# Altium schematic sheet Trottinette_Telemetrie_Circuit.SchDoc(Trottinette_Telemetrie_Circuit)
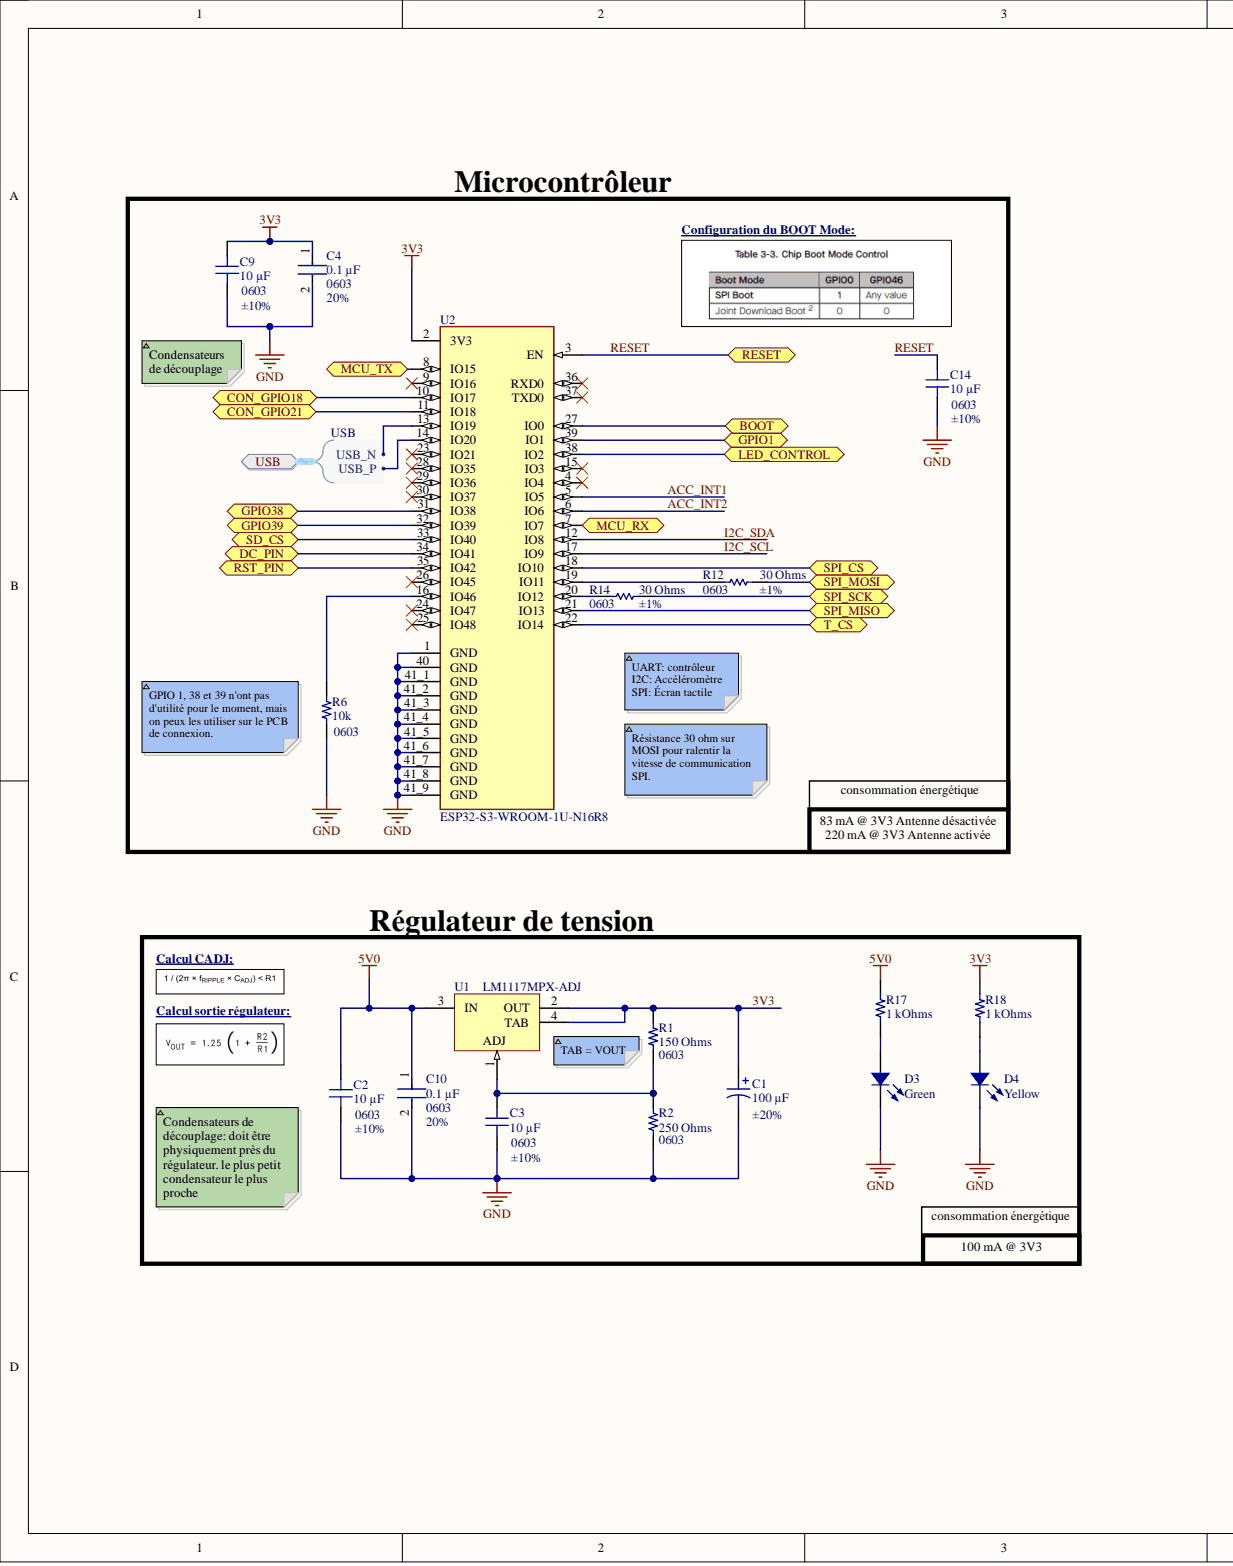
[diagram p0: Trottinette_Telemetrie_Circuit.SchDoc, left]
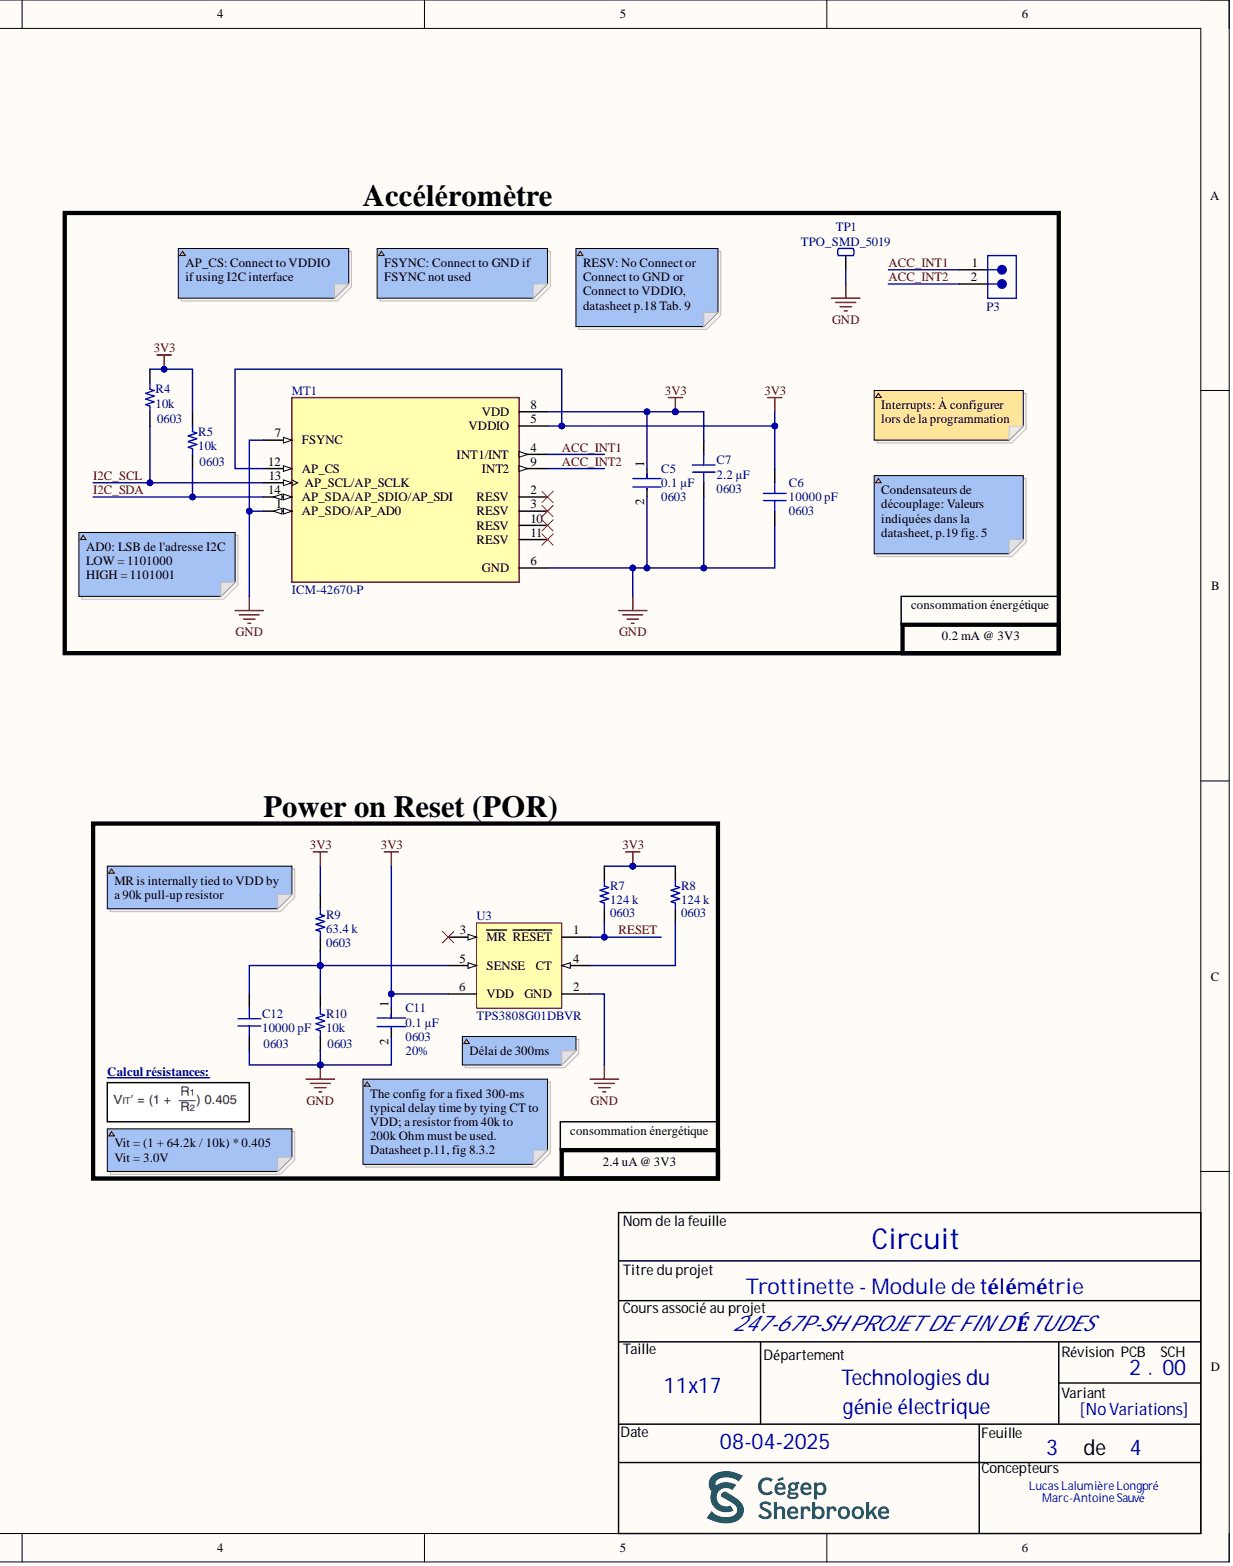
[diagram p1: Trottinette_Telemetrie_Circuit.SchDoc, right]

## Parts
C1  100 µF  Tolerance: ±20%  [CAP ALSMD 6.6MM H6.1MM]
C2  10 µF  Device Package: 0603  Tolerance: ±10%  [CAP 0603_1608]
C3  10 µF  Device Package: 0603  Tolerance: ±10%  [CAP 0603_1608]
C4  Capacitance: 0.1 µF  Case Code (Imperial): 0603  Tolerance: 20%  [FP-0603-L_1_6_0_15-W_0_81-IPC_B]  (hidden comment: 0603ZC104MAT2A)
C5  Case Code (Imperial): 0603  [FP-0603-L_1_6_0_15-W_0_81-IPC_B]  (hidden comment: 0603ZC104MAT2A)
C6  10000 pF  Package / Case: 0603  [CAP 0603_1608]
C7  2.2 µF  Package / Case: 0603  [CAP 0603_1608]
C9  10 µF  Device Package: 0603  Tolerance: ±10%  [CAP 0603_1608]
C10  Capacitance: 0.1 µF  Case Code (Imperial): 0603  Tolerance: 20%  [FP-0603-L_1_6_0_15-W_0_81-IPC_B]  (hidden comment: 0603ZC104MAT2A)
C11  Capacitance: 0.1 µF  Case Code (Imperial): 0603  Tolerance: 20%  [FP-0603-L_1_6_0_15-W_0_81-IPC_B]  (hidden comment: 0603ZC104MAT2A)
C12  10000 pF  Device Package: 0603  [CAP 0603_1608]
C14  10 µF  Device Package: 0603  Tolerance: ±10%  [CAP 0603_1608]
D3  Green  [LED 0603_1608 GREEN]
D4  Yellow  [LED 0603_1608 YELLOW]
MT1  ICM-42670-P  [XDCR_ICM-42670-P]
P3  61300211121  [61300211121]  (value not drawn)
R1  150 Ohms  Supplier Device Package: 0603  [RES 0603_1608]
R2  250 Ohms  Supplier Device Package: 0603  [RES 0603_1608]
R4  10k  Supplier Device Package: 0603  [RES 0603_1608]
R5  10k  Supplier Device Package: 0603  [RES 0603_1608]
R6  10k  Supplier Device Package: 0603  [RES 0603_1608]
R7  124 k  Supplier Device Package: 0603  [RES 0603_1608]
R8  124 k  Supplier Device Package: 0603  [RES 0603_1608]
R9  63.4 k  Supplier Device Package: 0603  [RES 0603_1608]
R10  10k  Supplier Device Package: 0603  [RES 0603_1608]
R12  ERJ3EKF30R0V  [RESC1608X55X30LL15T15]  (value not drawn)
R14  ERJ3EKF30R0V  [RESC1608X55X30LL15T15]  (value not drawn)
R17  1 kOhms  [RES 0603_1608]
R18  1 kOhms  [RES 0603_1608]
TP1  TPO_SMD_5019  [TPO_SMD_5019]
U1  LM1117MPX-ADJ  [MP04A_N]
U2  ESP32-S3-WROOM-1U-N16R8  [XCVR_ESP32-S3-WROOM-1U-N16R8]
U3  TPS3808G01DBVR  [DBV0006A_L]

## Ports
BOOT, CON_GPIO18, CON_GPIO21, DC_PIN, GPIO1, GPIO38, GPIO39, LED_CONTROL, MCU_RX, MCU_TX, RESET, RST_PIN, SD_CS, SPI_CS, SPI_MISO, SPI_MOSI, SPI_SCK, T_CS, USB, USB.USB_N, USB.USB_P

## Nets
5V0: C2.2 C10.1 R17.1 U1.3(IN)
GND: C1.2 C2.1 C3.1 C4.2 C5.2 C6.1 C7.1 C9.1 C10.2 C11.2 C12.1 C14.1 D3.2 D4.2 MT1.1(AP_SDO/AP_AD0) MT1.6(GND) MT1.7(FSYNC) R2.1 R6.1 R10.1 TP1.1 U2.1(GND) U2.40(GND) U2.41_1(GND) U2.41_2(GND) U2.41_3(GND) U2.41_4(GND) U2.41_5(GND) U2.41_6(GND) U2.41_7(GND) U2.41_8(GND) U2.41_9(GND) U3.2(GND)
3V3: C1.1 C4.1 C5.1 C6.2 C7.2 C9.2 C11.1 MT1.5(VDDIO) MT1.8(VDD) MT1.12(AP_CS) R1.2 R4.2 R5.2 R7.2 R8.2 R9.2 R18.1 U1.2(OUT) U1.4(TAB) U2.2(3V3) U3.6(VDD)
~{RESET}: C14.2 R7.1 U2.3(EN) U3.1(RESET) ; port RESET
ACC_INT1: MT1.4(INT1/INT) P3.1 U2.5(IO5)
ACC_INT2: MT1.9(INT2) P3.2 U2.6(IO6)
BOOT: U2.27(IO0) ; port BOOT
CON_GPIO18: U2.10(IO17) ; port CON_GPIO18
CON_GPIO21: U2.11(IO18) ; port CON_GPIO21
DC_PIN: U2.34(IO41) ; port DC_PIN
GPIO1: U2.39(IO1) ; port GPIO1
GPIO38: U2.31(IO38) ; port GPIO38
GPIO39: U2.32(IO39) ; port GPIO39
I2C_SCL: MT1.13(AP_SCL/AP_SCLK) R4.1 U2.17(IO9)
I2C_SDA: MT1.14(AP_SDA/AP_SDIO/AP_SDI) R5.1 U2.12(IO8)
LED_CONTROL: U2.38(IO2) ; port LED_CONTROL
MCU_RX: U2.7(IO7) ; port MCU_RX
MCU_TX: U2.8(IO15) ; port MCU_TX
RST_PIN: U2.35(IO42) ; port RST_PIN
SD_CS: U2.33(IO40) ; port SD_CS
SPI_CS: U2.18(IO10) ; port SPI_CS
SPI_MISO: U2.21(IO13) ; port SPI_MISO
SPI_MOSI: R12.2 ; port SPI_MOSI
SPI_SCK: R14.2 ; port SPI_SCK
T_CS: U2.22(IO14) ; port T_CS
USB.USB_N: U2.13(IO19) ; port USB
USB.USB_P: U2.14(IO20) ; port USB
NetC3_2: C3.2 R1.1 R2.2 U1.1
NetC12_2: C12.2 R9.1 R10.2 U3.5(SENSE)
NetD3_1: D3.1 R17.2
NetD4_1: D4.1 R18.2
NetR6_2: R6.2 U2.16(IO46)
NetR8_1: R8.1 U3.4(CT)
NetR12_1: R12.1 U2.19(IO11)
NetR14_1: R14.1 U2.20(IO12)

Not connected: MT1 2(RESV) 3(RESV) 10(RESV) 11(RESV); U2 4(IO4) 9(IO16) 15(IO3) 23(IO21) 24(IO47) 25(IO48) 26(IO45) 28(IO35) 29(IO36) 30(IO37) 36(RXD0) 37(TXD0); U3 3(MR)

## Library data of the parts
C1 = 10SGV100M6.3X6.1: CAP ALUM 100UF 20% 10V SMD; manufacturer: Rubycon; Database Table Name: Capacitors - Aluminum - Electrolytic SMT; Lifetime @ Temp.: 2000 Hrs @ 105°C; Polarization: Polar; Ripple Current @ High Frequency: 85.2 mA @ 10 kHz; Ripple Current @ Low Frequency: 71 mA @ 120 Hz; Surface Mount Land Size: 0.260" L x 0.260" W (6.60mm x 6.60mm); Applications: Automotive; Capacitance: 100 µF; Component Kind: Standard; componentlink1description: Datasheet; componentlink1url: http://www.rubycon.co.jp/en/catalog/e_pdfs/aluminum/e_sgv.pdf; componentlink2description: DigiKey Link; componentlink2url: https://www.digikey.com/en/products/detail/rubycon/10SGV100M6-3X6-1/3568604; Device Package: Radial, Can - SMD; Height - Seated (Max): 0.240" (6.10mm); lastupdated: 6/3/2024 8:16:10 PM; Library Name: Celestial Altium Library - altium_library.DbLib; Lifecycle Status: Active; Minimum Order: 1; Mounting Type: Surface Mount; Operating Temperature: -55°C ~ 105°C; Package / Case: Radial, Can - SMD; packaging: TapeAndReel; Part Number: 10SGV100M6.3X6.1; Part Status: Active; partid: 8082; price: 0.08; Ratings: AEC-Q200; series: SGV; Size / Dimension: 0.248" Dia (6.30mm); Supplier 1: DigiKey; Supplier Part Number 1: 1189-2392-1-ND; value: 100 µF; Voltage - Rated: 10 V
C2 C3 C9 C14 = GRT188R61A106KE13D: CAP CER 10UF 10V X5R 0603; manufacturer: Murata Electronics; Database Table Name: Capacitors - Ceramic - 0603; Applications: Automotive; Capacitance: 10 µF; Component Kind: Standard; componentlink1description: Datasheet; componentlink1url: https://search.murata.co.jp/Ceramy/image/img/A01X/G101/ENG/GRT188R61A106KE13-01.pdf; componentlink2description: DigiKey Link; componentlink2url: https://www.digikey.com/en/products/detail/murata-electronics/GRT188R61A106KE13D/5416733; Features: Derating Recommended; lastupdated: 2/9/2024 8:10:30 PM; Library Name: Celestial Altium Library - altium_library.DbLib; Lifecycle Status: Active; Minimum Order: 1; Mounting Type: Surface Mount, MLCC; Operating Temperature: -55°C ~ 85°C; Package / Case: 0603 (1608 Metric); packaging: TapeAndReel; Part Number: GRT188R61A106KE13D; Part Status: Active; partid: 2522; price: 0.06; Ratings: AEC-Q200; series: GRT; Size / Dimension: 0.063" L x 0.031" W (1.60mm x 0.80mm); Supplier 1: DigiKey; Supplier Part Number 1: 490-12311-1-ND; Temperature Coefficient: X5R; Thickness (Max): 0.037" (0.95mm); Value: 10 µF; Voltage - Rated: 10V
C4 C10 C11 = CMP-2006-04550-2: General Purpose Ceramic Capacitor, 0603, 100nF, 20%, X7R, 15%, 10V; Case Code (Metric): 1608; Case/Package: 0603; Component Kind: Standard; Construction: Flat; Depth: 0.81mm; Dielectric: X7R; Lead Free: Lead Free; Lead Pitch: 0.014inch; Length: 1.6mm; Library Name: Altium Content Vault; Max Operating Temperature: 125°C; Min Operating Temperature: -55°C; Mount: Surface Mount; Number of Pins: 2; Package Quantity: 4000; Packaging: Tape and Reel; Radiation Hardening: No; RoHS Compliant: Yes; Series: -; Termination: SMD/SMT; Thickness: 0.035inch; Voltage Rating: 10V; Voltage Rating (DC): 10V; Width: 0.032inch
C5 = CMP-2006-04550-2: General Purpose Ceramic Capacitor, 0603, 100nF, 20%, X7R, 15%, 10V; Capacitance: 0.1 µF; Case Code (Metric): 1608; Case/Package: 0603; Component Kind: Standard; Construction: Flat; Depth: 0.81mm; Dielectric: X7R; Lead Free: Lead Free; Lead Pitch: 0.014inch; Length: 1.6mm; Library Name: Altium Content Vault; Max Operating Temperature: 125°C; Min Operating Temperature: -55°C; Mount: Surface Mount; Number of Pins: 2; Package Quantity: 4000; Packaging: Tape and Reel; Radiation Hardening: No; RoHS Compliant: Yes; Series: -; Termination: SMD/SMT; Thickness: 0.035inch; Tolerance: 20%; Voltage Rating: 10V; Voltage Rating (DC): 10V; Width: 0.032inch
C6 = C0603C103K4RECAUTO: CAP CER 0603 10NF 16V X7R 10%; manufacturer: KEMET; Database Table Name: Capacitors - Ceramic - 0603; Applications: Automotive, ESD Protection; Capacitance: 10000 pF; Component Kind: Standard; componentlink1description: Datasheet; componentlink1url: https://api.kemet.com/component-edge/download/datasheet/C0603C103K4RECAUTO.pdf; componentlink2description: DigiKey Link; componentlink2url: https://www.digikey.com/en/products/detail/kemet/C0603C103K4RECAUTO/8640474; Device Package: 0603 (1608 Metric); lastupdated: 2/9/2024 8:10:22 PM; Library Name: Celestial Altium Library - altium_library.DbLib; Lifecycle Status: Active; Minimum Order: 1; Mounting Type: Surface Mount, MLCC; Operating Temperature: -55°C ~ 125°C; packaging: TapeAndReel; Part Number: C0603C103K4RECAUTO; Part Status: Active; partid: 8251; price: 0.01; Ratings: AEC-Q200; series: ESD SMD Auto X7R; Size / Dimension: 0.063" L x 0.031" W (1.60mm x 0.80mm); Supplier 1: DigiKey; Supplier Part Number 1: 399-17880-1-ND; Temperature Coefficient: X7R; Thickness (Max): 0.034" (0.87mm); Tolerance: ±10%; Value: 10000 pF; Voltage - Rated: 16V
C7 = CC0603ZRY5V7BB225: CAP CER 2.2UF 16V Y5V 0603; manufacturer: Yageo; Database Table Name: Capacitors - Ceramic - 0603; Temperature Coefficient: Y5V (F); Tolerance: -20%, +80%; Applications: General Purpose; Capacitance: 2.2 µF; Component Kind: Standard; componentlink1description: Datasheet; componentlink1url: http://www.yageo.com/documents/recent/UPY-GPHC_Y5V_6.3V-to-50V_8.pdf; componentlink2description: DigiKey Link; componentlink2url: https://www.digikey.com/en/products/detail/yageo/CC0603ZRY5V7BB225/5883851; Device Package: 0603 (1608 Metric); lastupdated: 2/9/2024 8:10:30 PM; Library Name: Celestial Altium Library - altium_library.DbLib; Lifecycle Status: Active; Minimum Order: 1; Mounting Type: Surface Mount, MLCC; Operating Temperature: -30°C ~ 85°C; packaging: TapeAndReel; Part Number: CC0603ZRY5V7BB225; Part Status: Active; partid: 2203; price: 0.01; series: CC; Size / Dimension: 0.063" L x 0.031" W (1.60mm x 0.80mm); Supplier 1: DigiKey; Supplier Part Number 1: 311-3416-1-ND; Thickness (Max): 0.035" (0.90mm); Value: 2.2 µF; Voltage - Rated: 16V
C12 = AC0603KRX7R0BB103: CAP CER 10000PF 100V X7R 0603; manufacturer: Yageo; Database Table Name: Capacitors - Ceramic - 0603; Tolerance: ±10%; Applications: Automotive; Capacitance: 10000 pF; Component Kind: Standard; componentlink1description: Datasheet; componentlink1url: http://www.yageo.com/NewPortal/_en/search/productDocs.jsp?YageoPartNumber=AC0603KRX7R0BB103; componentlink2description: DigiKey Link; componentlink2url: https://www.digikey.com/en/products/detail/yageo/AC0603KRX7R0BB103/6643086; lastupdated: 2024-02-09 20:10:30; Library Name: Celestial Altium Library - altium_library.DbLib; Lifecycle Status: Active; Minimum Order: 1; Mounting Type: Surface Mount, MLCC; Operating Temperature: -55°C ~ 125°C; Package / Case: 0603 (1608 Metric); packaging: TapeAndReel; Part Number: AC0603KRX7R0BB103; Part Status: Active; partid: 2218; price: 0.01; Ratings: AEC-Q200; series: AC; Size / Dimension: 0.063" L x 0.031" W (1.60mm x 0.80mm); Supplier 1: DigiKey; Supplier Part Number 1: 311-3100-1-ND; Temperature Coefficient: X7R; Thickness (Max): 0.035" (0.90mm); Value: 10000 pF; Voltage - Rated: 100V
D3 = 5973316507F: LED GREEN 0603 SMD; Manufacturer: Dialight; Configuration: Standard; Current - Test: 20mA; Database Table Name: LED - Indication; Lens Color: 1.24mm; Lens Style: Round with Domed Top; Millicandela Rating: 34mcd; Voltage - Forward (Vf) (Typ): 2V; Wavelength - Dominant: 560nm; Color: Green; Component Kind: Standard; ComponentLink1Description: Datasheet; ComponentLink1URL: http://www.dialightsignalsandcomponents.com/Assets/Drawings/2D_Drawings_DrawingDetailedSpec/NewDrawings/C18371C.pdf; ComponentLink2Description: DigiKey Link; ComponentLink2URL: https://www.digikey.com/en/products/detail/dialight/5973316507F/9385428; Device Package: 0603 (1608 Metric); Height (Max): 1.24mm; LastUpdated: 6/3/2024 7:58:53 PM; Library Name: Celestial Altium Library - altium_library.DbLib; Lifecycle Status: Active; Minimum Order: 1; Mounting Type: Surface Mount; Package / Case: 0603 (1608 Metric); Packaging: TapeAndReel; Part Number: 5973316507F; Part Status: Active; PartId: 587; Price: 0.13; Series: 597; Size / Dimension: 1.60mm L x 0.80mm W; Supplier 1: DigiKey; Supplier Device Package: 0603; Supplier Part Number 1: 350-4373-1-ND; Value: Green
D4 = 5990040007F: LED YELLOW 0603 SMD; Manufacturer: Dialight; Configuration: Standard; Current - Test: 20mA; Database Table Name: LED - Indication; Lens Color: 0.80mm; Lens Size: 1.10mm x 0.80mm; Lens Style: Rectangle with Flat Top; Millicandela Rating: 105mcd; Viewing Angle: 140°; Voltage - Forward (Vf) (Typ): 2.1V; Wavelength - Dominant: 590nm; Color: Yellow; Component Kind: Standard; ComponentLink1Description: Datasheet; ComponentLink1URL: http://www.dialightsignalsandcomponents.com/Assets/Brochures_And_Catalogs/Indication/CBI_SMD_new/Dialight_CBI_data_599-0603_Apr2018.pdf; ComponentLink2Description: DigiKey Link; ComponentLink2URL: https://www.digikey.com/en/products/detail/dialight/5990040007F/9385404; Device Package: 0603 (1608 Metric); Height (Max): 0.80mm; LastUpdated: 6/3/2024 8:01:10 PM; Library Name: Celestial Altium Library - altium_library.DbLib; Lifecycle Status: Active; Minimum Order: 1; Mounting Type: Surface Mount; Package / Case: 0603 (1608 Metric); Packaging: TapeAndReel; Part Number: 5990040007F; Part Status: Active; PartId: 83; Price: 0.04; Series: 599; Size / Dimension: 1.60mm L x 0.80mm W; Supplier 1: DigiKey; Supplier Device Package: 0603; Supplier Part Number 1: 350-4349-1-ND; Value: Yellow
P3 = 61300211121: WR-PHD Pin Header, THT, Vertical, pitch 2.54mm, 1 Row, 2P; Manufacturer: Wurth Elektronik; Contact Resistance: 20mOhm; Rated Current: 3A; Withstanding Voltage: 500V; Working Voltage: 250V; Category: Board-to-Board Connectors; Component Kind: Standard; ComponentLink1Description: Website Link; ComponentLink1URL: https://www.we-online.com/redexpert/article/61300211121?ad; ComponentLink2Description: Datasheet Link; ComponentLink2URL: https://www.we-online.com/redexpert/spec/61300211121?ad; Gender: Pin Header; Library Name: Altium_WR-PHD_rev24a.IntLib; Manufacturer Part Number: 61300211121; Match Code: WR-PHD; Mount: Through Hole; Number of Pins: 2; Number of Rows: 1; Operating Temperature Max: 105°C; Operating Temperature Min: -40°C; Orientation: Vertical; Packaging: Bag; Pitch: 2.54mm
R1 = RMCF0603FT150R: RES 150 OHM 1% 1/10W 0603; Manufacturer: Stackpole Electronics Inc; Database Table Name: Resistors - Surface Mount - 0603; Power (Watts): 0.1W, 1/10W; Temperature Coefficient: ±100ppm/°C; Component Kind: Standard; ComponentLink1Description: Datasheet; ComponentLink1URL: https://www.seielect.com/catalog/sei-rmcf_rmcp.pdf; ComponentLink2Description: DigiKey Link; ComponentLink2URL: https://www.digikey.com/en/products/detail/stackpole-electronics-inc/RMCF0603FT150R/1761314; Composition: Thick Film; Device Package: 0603 (1608 Metric); Features: Automotive AEC-Q200; Height - Seated (Max): 0.022" (0.55mm); LastUpdated: 2/9/2024 8:43:14 PM; Library Name: Celestial Altium Library - altium_library.DbLib; Lifecycle Status: Active; Minimum Order: 1; Number of Terminations: 2; Operating Temperature: -55°C ~ 155°C; Package / Case: 0603 (1608 Metric); Packaging: CutTape; Part Number: RMCF0603FT150R; Part Status: Active; PartId: 10675; Price: 0.01; Ratings: AEC-Q200; Resistance: 150 Ohms; Series: RMCF; Size / Dimension: 0.061" L x 0.031" W (1.55mm x 0.80mm); Supplier 1: DigiKey; Supplier Part Number 1: RMCF0603FT150RCT-ND; Tolerance: ±1%; Value: 150 Ohms
R2 = CH0603-250RJNTA: RES 250 OHM 5% 1/8W 0603; Manufacturer: Vishay Sfernice; Database Table Name: Resistors - Surface Mount - 0603; Power (Watts): 0.125W, 1/8W; Temperature Coefficient: ±100ppm/°C; Component Kind: Standard; ComponentLink1Description: Datasheet; ComponentLink1URL: http://www.vishay.com/docs/53014/ch.pdf; ComponentLink2Description: DigiKey Link; ComponentLink2URL: https://www.digikey.com/en/products/detail/vishay-sfernice/CH0603-250RJNTA/9405751; Composition: Thin Film; Device Package: 0603 (1608 Metric); Features: RF, High Frequency; Height - Seated (Max): 0.025" (0.63mm); LastUpdated: 2/9/2024 8:43:16 PM; Library Name: Celestial Altium Library - altium_library.DbLib; Lifecycle Status: Active; Minimum Order: 1; Number of Terminations: 2; Operating Temperature: -55°C ~ 155°C; Package / Case: 0603 (1608 Metric); Packaging: TapeAndReel; Part Number: CH0603-250RJNTA; Part Status: Active; PartId: 10119; Price: 2.39; Resistance: 250 Ohms; Series: CH; Size / Dimension: 0.060" L x 0.030" W (1.52mm x 0.76mm); Supplier 1: DigiKey; Supplier Part Number 1: 716-1168-1-ND; Tolerance: ±5%; Value: 250 Ohms
R4-R6 R10 = RNCS0603BKE10K0: RES 10K OHM 0.1% 1/16W 0603; Manufacturer: Stackpole Electronics Inc; Database Table Name: Resistors - Surface Mount - 0603; Power (Watts): 0.063W, 1/16W; Resistance: 10 kOhms; Temperature Coefficient: ±25ppm/°C; Component Kind: Standard; ComponentLink1Description: Datasheet; ComponentLink1URL: https://www.seielect.com/catalog/sei-rncs_rnch.pdf; ComponentLink2Description: DigiKey Link; ComponentLink2URL: https://www.digikey.com/en/products/detail/stackpole-electronics-inc/RNCS0603BKE10K0/1708836; Composition: Thin Film; Device Package: 0603 (1608 Metric); Features: Anti-Corrosive, Automotive AEC-Q200, Moisture Resistant; Height - Seated (Max): 0.022" (0.55mm); LastUpdated: 2/9/2024 8:43:37 PM; Library Name: Celestial Altium Library - altium_library.DbLib; Lifecycle Status: Active; Minimum Order: 1; Number of Terminations: 2; Operating Temperature: -55°C ~ 155°C; Package / Case: 0603 (1608 Metric); Packaging: TapeAndReel; Part Number: RNCS0603BKE10K0; Part Status: Active; PartId: 4838; Price: 0.06; Ratings: AEC-Q200; Series: RNCS; Size / Dimension: 0.061" L x 0.031" W (1.55mm x 0.80mm); Supplier 1: DigiKey; Supplier Part Number 1: RNCS0603BKE10K0CT-ND; Tolerance: ±0.1%; Value: 10k
R7 R8 = RMCF0603FT124K: RES 124K OHM 1% 1/10W 0603; Manufacturer: Stackpole Electronics Inc; Database Table Name: Resistors - Surface Mount - 0603; Power (Watts): 0.1W, 1/10W; Resistance: 124 kOhms; Temperature Coefficient: ±100ppm/°C; Component Kind: Standard; ComponentLink1Description: Datasheet; ComponentLink1URL: https://www.seielect.com/catalog/sei-rmcf_rmcp.pdf; ComponentLink2Description: DigiKey Link; ComponentLink2URL: https://www.digikey.com/en/products/detail/stackpole-electronics-inc/RMCF0603FT124K/1761224; Composition: Thick Film; Device Package: 0603 (1608 Metric); Features: Automotive AEC-Q200; Height - Seated (Max): 0.022" (0.55mm); LastUpdated: 2/9/2024 8:43:13 PM; Library Name: Celestial Altium Library - altium_library.DbLib; Lifecycle Status: Active; Minimum Order: 1; Number of Terminations: 2; Operating Temperature: -55°C ~ 155°C; Package / Case: 0603 (1608 Metric); Packaging: CutTape; Part Number: RMCF0603FT124K; Part Status: Active; PartId: 10853; Price: 0.01; Ratings: AEC-Q200; Series: RMCF; Size / Dimension: 0.061" L x 0.031" W (1.55mm x 0.80mm); Supplier 1: DigiKey; Supplier Part Number 1: RMCF0603FT124KCT-ND; Tolerance: ±1%; Value: 124 k
R9 = RMCF0603FT63K4: RES 63.4K OHM 1% 1/10W 0603; Manufacturer: Stackpole Electronics Inc; Database Table Name: Resistors - Surface Mount - 0603; Power (Watts): 0.1W, 1/10W; Resistance: 63.4 kOhms; Temperature Coefficient: ±100ppm/°C; Component Kind: Standard; ComponentLink1Description: Datasheet; ComponentLink1URL: https://www.seielect.com/catalog/sei-rmcf_rmcp.pdf; ComponentLink2Description: DigiKey Link; ComponentLink2URL: https://www.digikey.com/en/products/detail/stackpole-electronics-inc/RMCF0603FT63K4/1714177; Composition: Thick Film; Device Package: 0603 (1608 Metric); Features: Automotive AEC-Q200; Height - Seated (Max): 0.022" (0.55mm); LastUpdated: 2/9/2024 8:43:20 PM; Library Name: Celestial Altium Library - altium_library.DbLib; Lifecycle Status: Active; Minimum Order: 1; Number of Terminations: 2; Operating Temperature: -55°C ~ 155°C; Package / Case: 0603 (1608 Metric); Packaging: CutTape; Part Number: RMCF0603FT63K4; Part Status: Active; PartId: 8895; Price: 0.01; Ratings: AEC-Q200; Series: RMCF; Size / Dimension: 0.061" L x 0.031" W (1.55mm x 0.80mm); Supplier 1: DigiKey; Supplier Part Number 1: RMCF0603FT63K4CT-ND; Tolerance: ±1%; Value: 63.4 k
R12 R14 = CMP-2000-00423-1: Manufacturer: Panasonic; Component Kind: Standard; Library Name: Altium Content Vault; Part Number: ERJ3EKF30R0V
R17 R18 = CPF0603B1K0E: RES SMD 1K OHM 0.1% 1/16W 0603; Manufacturer: TE Connectivity Passive Product; Database Table Name: Resistors - Surface Mount - 0603; Power (Watts): 0.063W, 1/16W; Temperature Coefficient: ±25ppm/°C; Component Kind: Standard; ComponentLink1Description: Datasheet; ComponentLink1URL: https://www.te.com/commerce/DocumentDelivery/DDEController?Action=srchrtrv&DocNm=1773200&DocType=DS&DocLang=English; ComponentLink2Description: DigiKey Link; ComponentLink2URL: https://www.digikey.com/en/products/detail/te-connectivity-passive-product/CPF0603B1K0E/2390473; Composition: Thin Film; Device Package: 0603 (1608 Metric); Height - Seated (Max): 0.022" (0.55mm); LastUpdated: 2/9/2024 8:43:37 PM; Library Name: Celestial Altium Library - altium_library.DbLib; Lifecycle Status: Active; Minimum Order: 1; Number of Terminations: 2; Operating Temperature: -55°C ~ 155°C; Package / Case: 0603 (1608 Metric); Packaging: TapeAndReel; Part Number: CPF0603B1K0E; Part Status: Active; PartId: 4877; Price: 0.09; Resistance: 1 kOhms; Series: CPF, Neohm; Size / Dimension: 0.061" L x 0.031" W (1.55mm x 0.80mm); Supplier 1: DigiKey; Supplier Device Package: 0603; Supplier Part Number 1: A119887CT-ND; Tolerance: ±0.1%; Value: 1 kOhms
TP1 = CMP-007-000000-1: TPO - PC TEST POINT MINI - SMD; Manufacturer #1: Keystone Electronics; Part Number #1: 5019; Polarized: No; Value: 3.81mm x 2.03mm; Assembly Type: SMD; Component Kind: Standard; Component Type: Standard; Design Item ID: TPO_SMD_5019; HelpURL: https://www.digikey.ca/product-detail/en/keystone-electronics/5019/36-5019CT-ND/3907344; Package: SMD; Pin Count: 1; Library Name: Cegep de Sherbrooke; Source: CEGEP_SHERBROOKE_TGE_LIBRARY.SchLib
U1 = CMP-0062-01642-3: 800mA Low-Dropout Linear Regulator, 4-pin SOT-223; Manufacturer: National Semiconductor; CompType: LDO IC; High-Reliability: Y; PackageTopMarking: XYTT*N03A; PowerWise: N; Code_IPC: SOT223-4; Code_JEDEC: TO-261-AA; Component Kind: Standard; ComponentLink1Description: Manufacturer URL; ComponentLink1URL: http://www.national.com/; ComponentLink2Description: LM1117 Datasheet; ComponentLink2URL: http://www.national.com/ds/LM/LM1117.pdf; ComponentLink3Description: LM1117 Web Page; ComponentLink3URL: http://www.national.com/pf/LM/LM1117.html; ComponentLink4Description: MP04A Package Page; ComponentLink4URL: http://www.national.com/packaging/folders/mp04a.html; DatasheetVersion: Apr-2006; Library Name: Altium Content Vault; PackageDescription: Molded SOT-223, 6.50 x 3.56 x 1.6mm Body, 4 Lead, 2.3mm Pitch; PackageReference: MP04A; PackageVersion: revB, Apr-2003; PartNumber: LM1117MPX-ADJ; PinCount: 4; RevisionNote: SCH symbol layout adjusted; RoHS: N
U2 = ESP32-S3-WROOM-1U-N16R8: Bluetooth, WiFi 802.11b/g/n, Bluetooth v5.0 Transceiver Module 2.4GHz Antenna Not Included, U.FL Surface Mount; MF: Espressif Systems; Availability: Unavailable; Check_prices: https://www.snapeda.com/parts/ESP32-S3-WROOM-1U-N16R8/Espressif+Systems/view-part/?ref=eda; Component Kind: Standard; Library Name: ESP32-S3-WROOM-1U-N16R8.IntLib; MP: ESP32-S3-WROOM-1U-N16R8; Package: None; Price: None; SnapEDA_Link: https://www.snapeda.com/parts/ESP32-S3-WROOM-1U-N16R8/Espressif+Systems/view-part/?ref=snap
U3 = CMP-0332-00558-2: Low Quiescent Current, Programmable-Delay Supervisory Circuit, 1 Supply Monitored, -40 to 125 degC, 6-pin SOT-23 (DBV), Green (RoHS & no Sb/Br); Manufacturer: Texas Instruments; # of Supplies Monitored: 1; Iq(Typ)(uA): 2.4; Output Driver Type / Reset Output: Active-low, Open-drain; Rating: Catalog; SubFamily: Supervisor and Reset IC; Threshold Voltage 1 (typ)(Typ)(V): Adjustable; VCC(Max)(V): 6.5; VCC(Min)(V): 1.7; Code_JEDEC: MO-178-AB*; Component Kind: Standard; ComponentLink1Description: Datasheet; ComponentLink1URL: http://www.ti.com/general/docs/lit/getliterature.tsp?genericPartNumber=TPS3808G01&fileType=pdf; DatasheetVersion: SBVS050J; Library Name: Altium Content Vault; Mounting Technology: Surface Mount; PackageDescription: 6-Pin Plastic Small-Outline Package, 2.9 x 1.6 mm Body, 0.95 mm Pitch, 2.8 mm Lead Span; PackageReference: DBV0006A; PackageVersion: revK, Mar-2006; PartNumber: TPS3808G01DBVR; Reset Threshold Accuracy(%): 1; Time Delay(ms): Programmable
MT1 = ICM-42670-P: Availability: Unavailable; Component Kind: Standard; Library Name: ICM-42670-P.IntLib; MP: ICM-42670-P; Package: None; Price: None
